# Altium schematic sheet EMG_Sensors_Temp.SchDoc
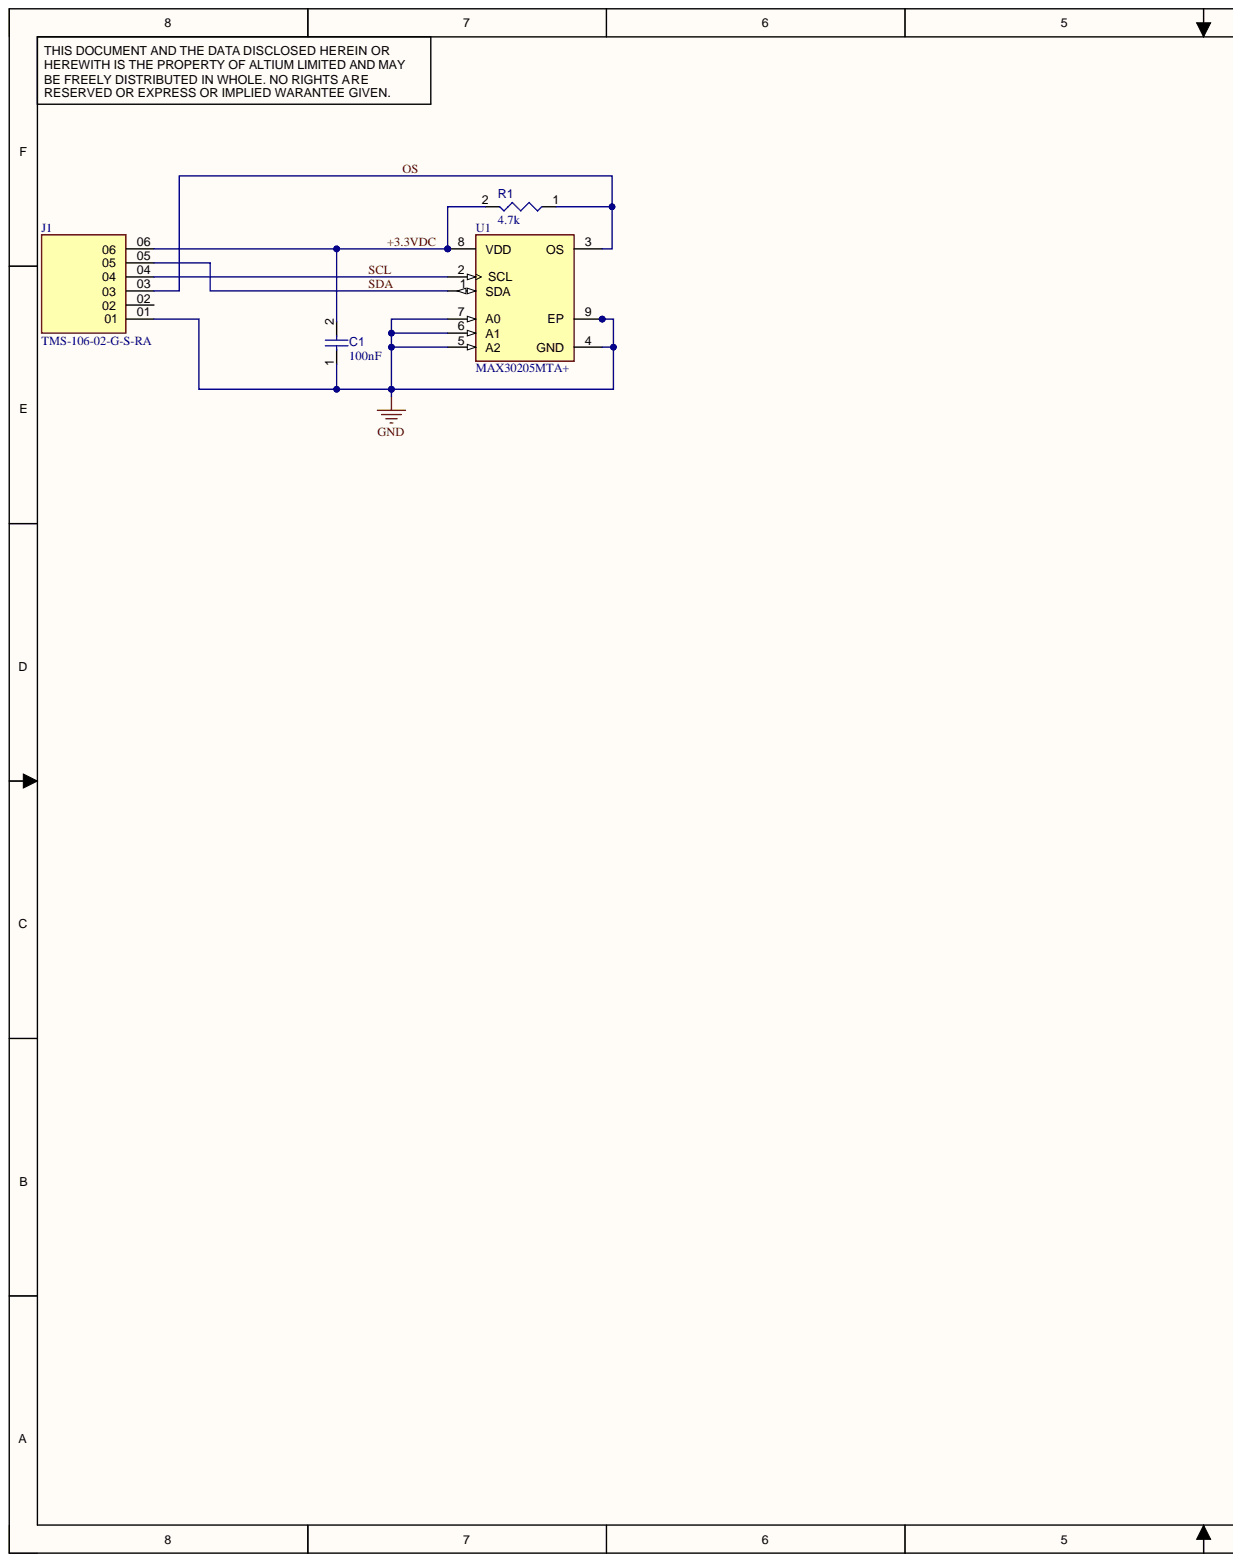
[diagram p0: EMG_Sensors_Temp.SchDoc, left]
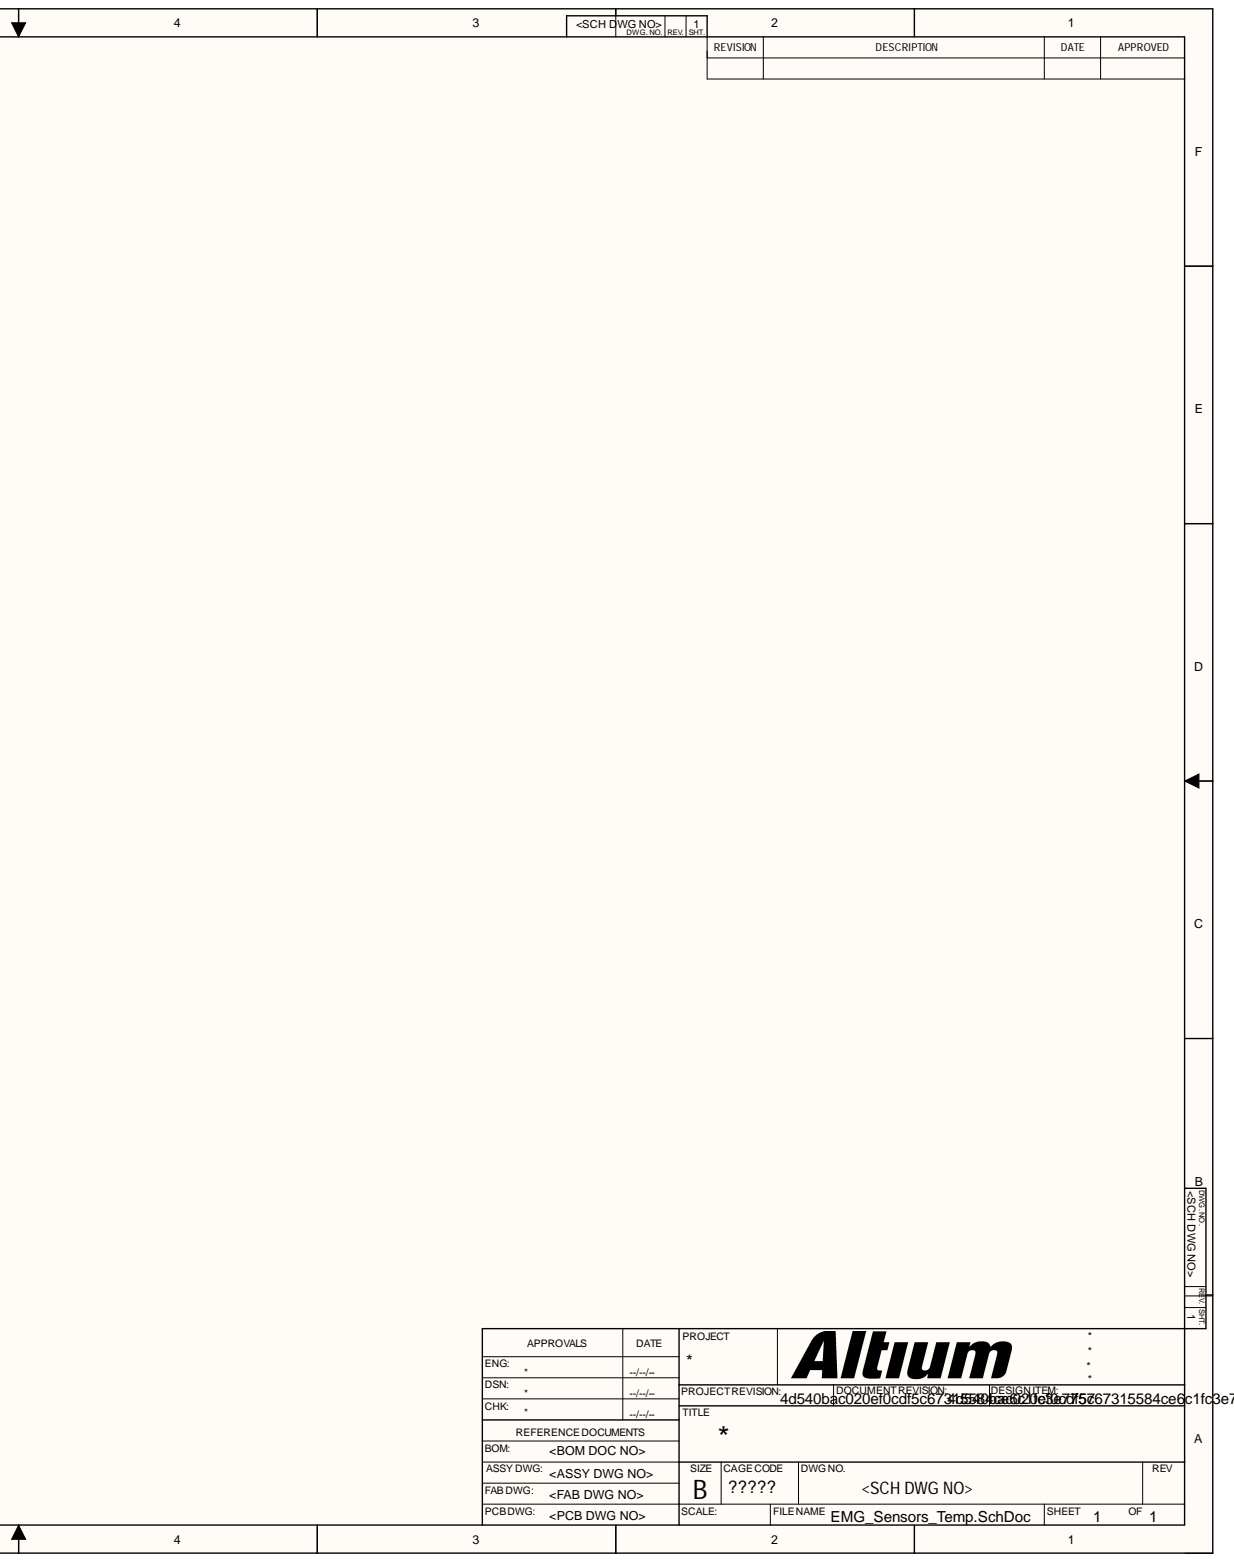
[diagram p1: EMG_Sensors_Temp.SchDoc, right]

## Parts
C1  value 100nF  [CAPC1005X56X25LL10T15]  (hidden comment: Capacitor 0.1 uF +/- 5% 16 V 0402)
J1  TMS-106-02-G-S-RA  [SAMTEC_TMS-106-02-G-S-RA]
R1  value 4.7k  [RESC1005X40X25ML05T05]  (hidden comment: Resistor 4k7 +/-1% 0402 63 mW)
U1  MAX30205MTA+  [FP-T833-2-MFG]

## Nets
GND: C1.1 J1.01 U1.4(GND) U1.5(A2) U1.6(A1) U1.7(A0) U1.9(EP)
+3.3VDC: C1.2 J1.06 R1.2 U1.8(VDD)
OS: J1.03 R1.1 U1.3(OS)
SCL: J1.04 U1.2(SCL)
SDA: J1.05 U1.1(SDA)

Not connected: J1 02

## Library data of the parts
C1 = CMP-001-00001-6: Chip Capacitor, 0.1 uF, +/- 5%, 16 V, 0402 (1005 Metric); Capacitor Type: Ceramic; Voltage Rating: 16V; Case/Package: 0402; Component Kind: Standard; Max Operating Temperature: 125°C; Min Operating Temperature: -55°C; Mounting Technology: SM; Pins: 2; RoHS Compliant: True; Tolerance: 5%; Library Name: Education
R1 = CMP-009-00034-5: Chip Resistor, 4.7 KOhm, +/- 1%, 63 mW, -55 to 155 degC, 0402 (1005 Metric); Power: 63mW; Voltage Rating: 50V; Case/Package: 0402; Component Kind: Standard; Max Operating Temperature: 155°C; Min Operating Temperature: -55°C; Mounting Technology: SM; Pins: 2; RoHS Compliant: True; Tolerance: 1%; Library Name: Education
U1 = CMP-05752-000001-1: SENSOR DIGITAL 0C-50C 8TDFN; Manufacturer: Maxim Integrated; Interface: I2C,2-Wire,SMBus; Max Junction Temp: +50°C; Max Supply Voltage: 3.3V; Min Supply Voltage: 2.7V; Operating Supply Current: 600uA; Resolution: 16bits; Sensor Output: I2C; Standoff Height: 0mm; Automotive: No; Category: IC; Component Kind: Standard; ComponentLink2Description: Datasheet; ComponentLink2URL: https://datasheets.maximintegrated.com/en/ds/MAX30205.pdf; Device Class L1: Sensors; Device Class L2: Temperature and Humidity Sensors; Device Class L3: unset; Digikey Description: SENSOR DIGITAL 0C-50C 8TDFN; Footprint Url: https://pdfserv.maximintegrated.com/land_patterns/90-0059.PDF; Height: 0.8mm; Ipc Land Pattern Name: DFN300X300X75-8; Lead Free: Yes; Library Name: Altium Content Vault; Manufacturer Part Number: MAX30205MTA+; Octopart: https://octopart.com/max30205mta%2B-maxim+integrated-66461181; Package: T833-2; RoHS: TRUE; Temperature: 0°C to +50°C; Temperature Range High: +50°C; Temperature Range Low: 0°C
J1 = TMS-106-02-G-S-RA: Availability: Unavailable; Component Kind: Standard; Description: TMS-106-02-G-S-RA; Library Name: TMS-106-02-G-S-RA.IntLib; MP: TMS-106-02-G-S-RA; Package: None; Price: None
